# Altium schematic sheet enc-abs_I2C.SchDoc(enc-abs_I2C)
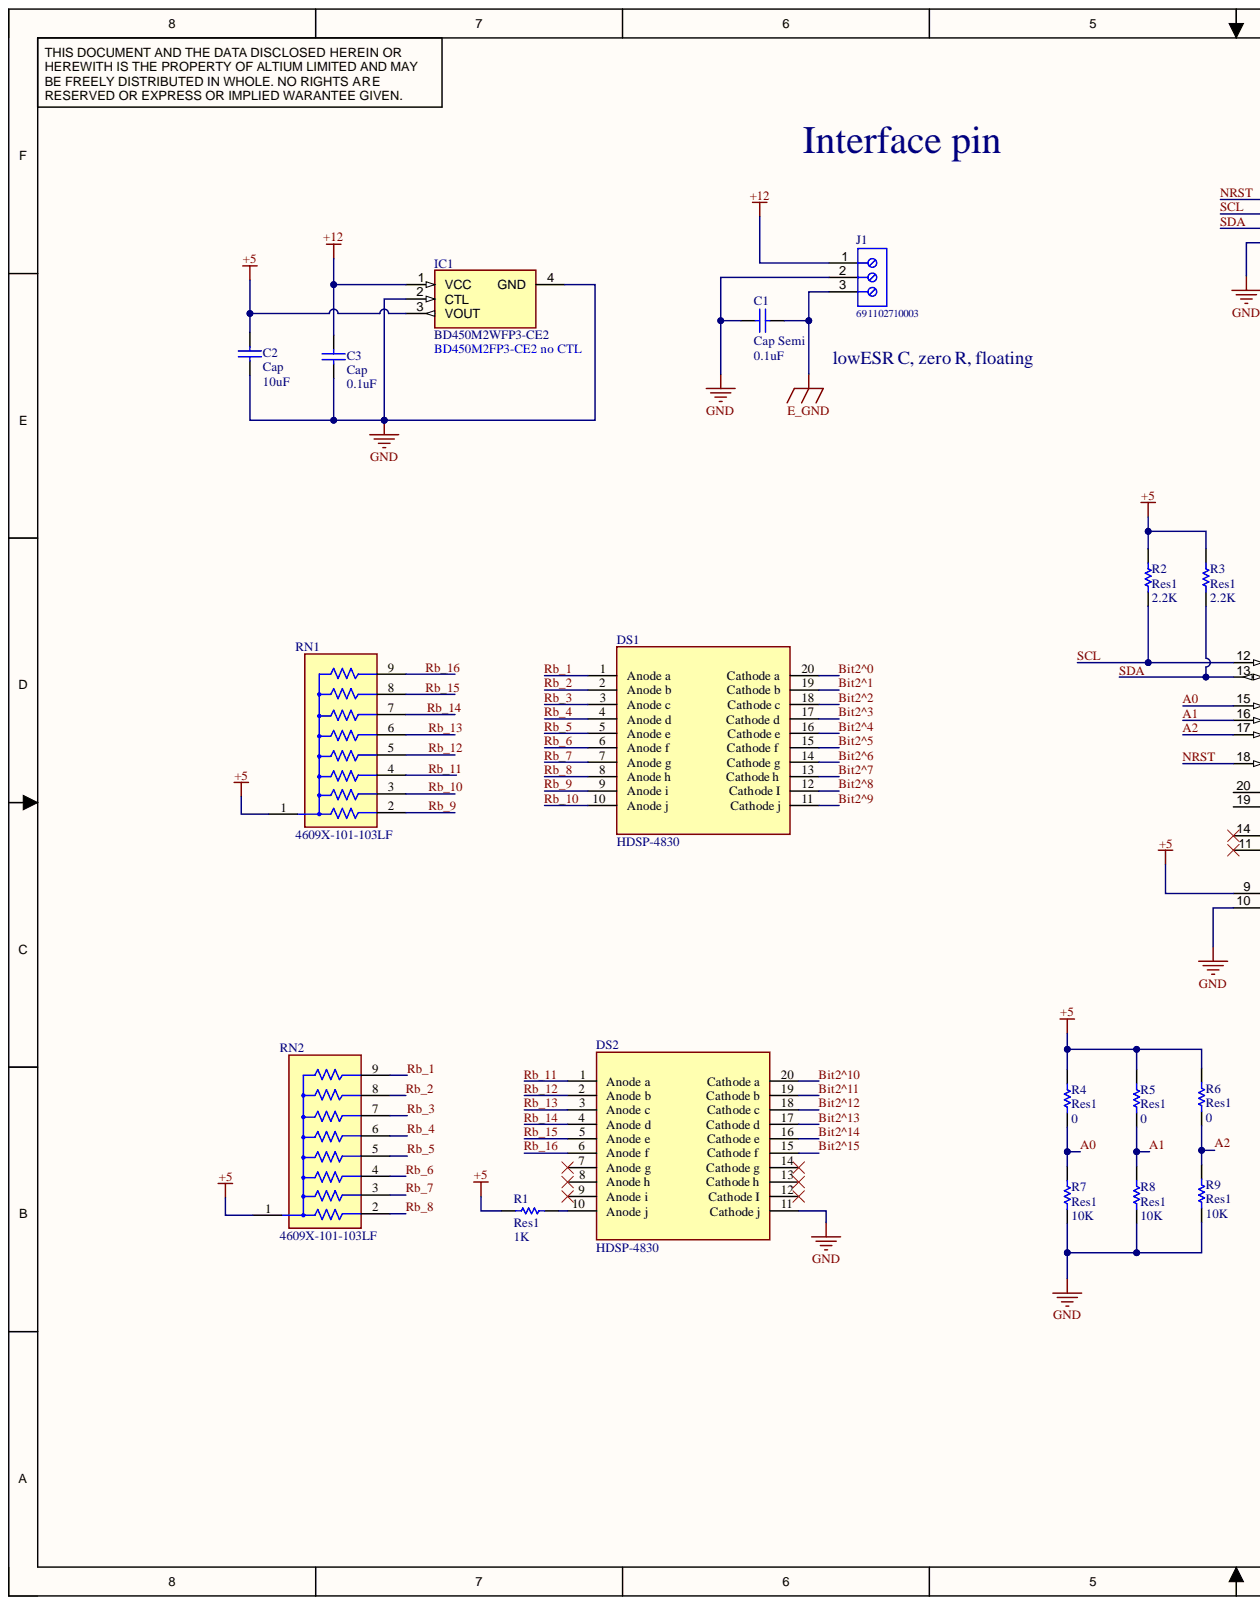
[diagram p0: enc-abs_I2C.SchDoc, left]
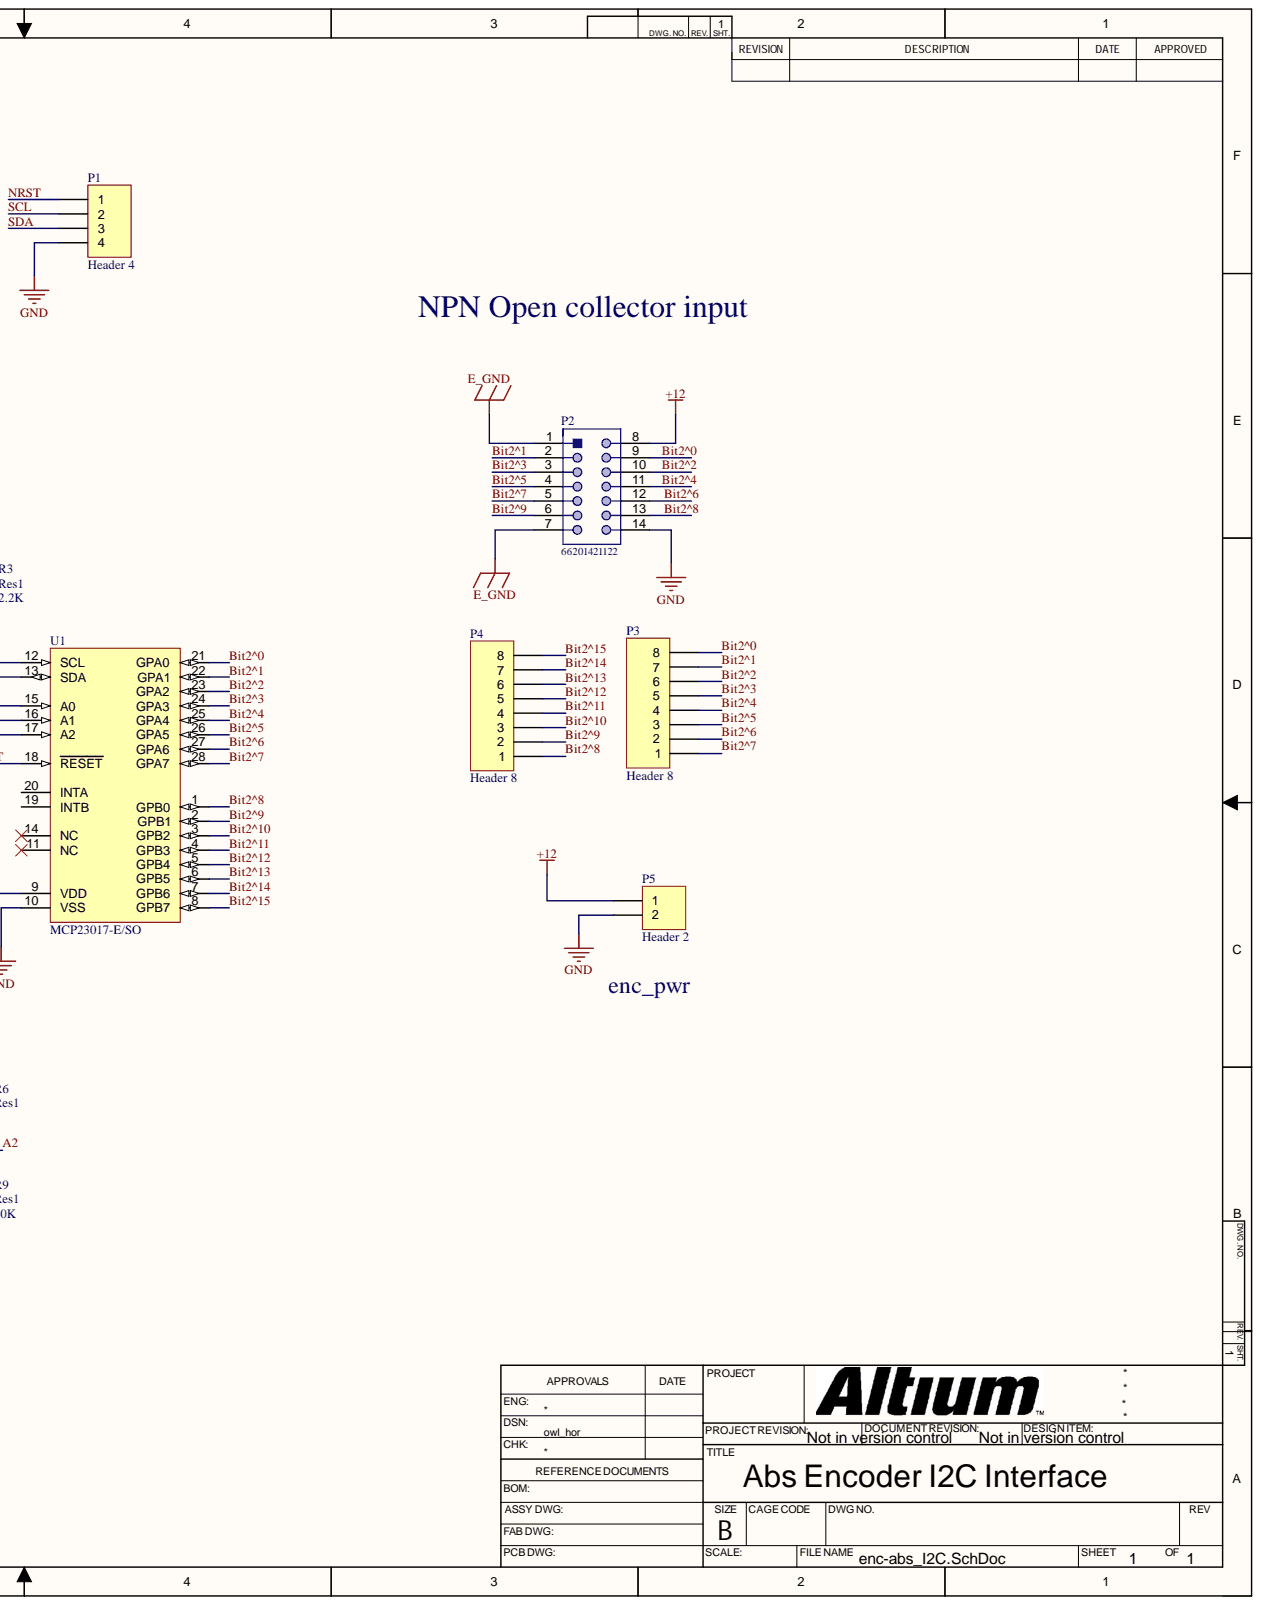
[diagram p1: enc-abs_I2C.SchDoc, right]

## Parts
C1  Cap Semi  value 0.1uF  [C1206]
C2  Cap  value 10uF  [RAD-0.3]
C3  Cap  value 0.1uF  [RAD-0.3]
DS1  HDSP-4830  [Broadcom_HDSP-4830_0]
DS2  HDSP-4830  [Broadcom_HDSP-4830_0]
IC1  BD450M2WFP3-CE2  [SOT230P700X170-4N]
J1  691102710003  [691102710003]
P1  Header 4  [HDR1X4]
P2  66201421122  [66201421122]
P3  Header 8  [HDR1X8]
P4  Header 8  [HDR1X8]
P5  Header 2  [HDR1X2]
R1  Res1  value 1K  [Res_1206_3mm]
R2  Res1  value 2.2K  [AXIAL-0.3]
R3  Res1  value 2.2K  [AXIAL-0.3]
R4  Res1  value 0  [AXIAL-0.3]
R5  Res1  value 0  [AXIAL-0.3]
R6  Res1  value 0  [AXIAL-0.3]
R7  Res1  value 10K  [AXIAL-0.3]
R8  Res1  value 10K  [AXIAL-0.3]
R9  Res1  value 10K  [AXIAL-0.3]
RN1  4609X-101-103LF  [FP-4609X-MFG]
RN2  4609X-101-103LF  [FP-4609X-MFG]
U1  MCP23017-E/SO  [SOIC-SO28_N]

## Nets
+5: C2.2 IC1.3(VOUT) R1.2 R2.2 R3.2 R4.2 R5.2 R6.2 RN1.1 RN2.1 U1.9(VDD)
+12: C3.2 IC1.1(VCC) J1.1 P2.8 P5.1
E_GND: C1.2 J1.3 P2.1 P2.7
GND: C1.1 C2.1 C3.1 DS2.11(Cathode_j) IC1.2(CTL) IC1.4(GND) J1.2 P1.4 P2.14 P5.2 R7.1 R8.1 R9.1 U1.10(VSS)
A0: R4.1 R7.2 U1.15(A0)
A1: R5.1 R8.2 U1.16(A1)
A2: R6.1 R9.2 U1.17(A2)
Bit2^0: DS1.20(Cathode_a) P2.9 P3.8 U1.21(GPA0)
Bit2^1: DS1.19(Cathode_b) P2.2 P3.7 U1.22(GPA1)
Bit2^2: DS1.18(Cathode_c) P2.10 P3.6 U1.23(GPA2)
Bit2^3: DS1.17(Cathode_d) P2.3 P3.5 U1.24(GPA3)
Bit2^4: DS1.16(Cathode_e) P2.11 P3.4 U1.25(GPA4)
Bit2^5: DS1.15(Cathode_f) P2.4 P3.3 U1.26(GPA5)
Bit2^6: DS1.14(Cathode_g) P2.12 P3.2 U1.27(GPA6)
Bit2^7: DS1.13(Cathode_h) P2.5 P3.1 U1.28(GPA7)
Bit2^8: DS1.12(Cathode_I) P2.13 P4.1 U1.1(GPB0)
Bit2^9: DS1.11(Cathode_j) P2.6 P4.2 U1.2(GPB1)
Bit2^10: DS2.20(Cathode_a) P4.3 U1.3(GPB2)
Bit2^11: DS2.19(Cathode_b) P4.4 U1.4(GPB3)
Bit2^12: DS2.18(Cathode_c) P4.5 U1.5(GPB4)
Bit2^13: DS2.17(Cathode_d) P4.6 U1.6(GPB5)
Bit2^14: DS2.16(Cathode_e) P4.7 U1.7(GPB6)
Bit2^15: DS2.15(Cathode_f) P4.8 U1.8(GPB7)
NRST: P1.1 U1.18(RESET)
Rb_1: DS1.1(Anode_a) RN2.9
Rb_2: DS1.2(Anode_b) RN2.8
Rb_3: DS1.3(Anode_c) RN2.7
Rb_4: DS1.4(Anode_d) RN2.6
Rb_5: DS1.5(Anode_e) RN2.5
Rb_6: DS1.6(Anode_f) RN2.4
Rb_7: DS1.7(Anode_g) RN2.3
Rb_8: DS1.8(Anode_h) RN2.2
Rb_9: DS1.9(Anode_i) RN1.2
Rb_10: DS1.10(Anode_j) RN1.3
Rb_11: DS2.1(Anode_a) RN1.4
Rb_12: DS2.2(Anode_b) RN1.5
Rb_13: DS2.3(Anode_c) RN1.6
Rb_14: DS2.4(Anode_d) RN1.7
Rb_15: DS2.5(Anode_e) RN1.8
Rb_16: DS2.6(Anode_f) RN1.9
SCL: P1.2 R2.1 U1.12(SCL)
SDA: P1.3 R3.1 U1.13(SDA)
NetDS2_10: DS2.10(Anode_j) R1.1

Not connected: DS2 7(Anode_g) 8(Anode_h) 9(Anode_i) 12(Cathode_I) 13(Cathode_h) 14(Cathode_g); U1 11(NC) 14(NC) 19(INTB) 20(INTA)

## Library data of the parts
C1 = Cap Semi: Capacitor (Semiconductor SIM Model); Component Kind: Standard; LatestRevisionDate: 29-May-2009; LatestRevisionNote: IPC-7351 Footprint Added.; Library Name: Miscellaneous Devices.IntLib; PackageDescription: Chip Capacitor; PackageReference: C1206; Published: 8-Jun-2000; Signal Integrity: Cap Semi; Simulation: CAP; Publisher: Altium Limited
C2 C3 = Cap: Capacitor; Component Kind: Standard; LatestRevisionDate: 17-Jul-2002; LatestRevisionNote: Re-released for DXP Platform.; Library Name: Miscellaneous Devices.IntLib; PackageDescription: Radial Cap, Thru-Hole; 2 Leads; 0.3 in Pin Spacing; PackageReference: RAD-0.3; Published: 8-Jun-2000; Signal Integrity: Cap; Simulation: CAP; Publisher: Altium Limited
DS1 DS2 = HDSP-4830: 10 Segments LED Array; Component Kind: Standard; Library Name: Schlib1.SchLib
IC1 = BD450M2WFP3-CE2: Integrated Circuit; Manufacturer_Name: ROHM Semiconductor; Component Kind: Standard; Datasheet Link: https://componentsearchengine.com/Datasheets/1/BD450M2WFP3-CE2.pdf; Height: 1.7mm; Manufacturer_Part_Number: BD450M2WFP3-CE2; Mouser Part Number: 755-BD450M2WFP3-CE2; Mouser Price/Stock: https://www.mouser.co.uk/ProductDetail/ROHM-Semiconductor/BD450M2WFP3-CE2?qs=pXoXL5qUNJ0Rp1aMnIGBZQ%3D%3D
J1 = CMP-1502-03259-1: Series 102 - 5.00 mm Horizontal Entry Modular with Pressure Clamp WR-TBL, 3 pin; Manufacturer: Wurth Elektronik; Pin Number: 3; Pitch: 5.00mm; Component Kind: Standard; Datasheet URL: http://katalog.we-online.de/em/datasheet/6911027100xx.pdf; Library Name: Altium Content Vault; Manufacturer URL: http://www.we-online.de; Mounting Technology: THT; Operating Temperature: -40to105degC; Package Reference: 691102710003; Packaging: Box; Part Number: 691102710003; Publisher: WurthElektronik
P1 = Header 4: Header, 4-Pin; Component Kind: Standard; LatestRevisionDate: 17-Jul-2002; LatestRevisionNote: Re-released for DXP Platform.; Library Name: Miscellaneous Connectors.IntLib; Signal Integrity: Connector; Publisher: Altium Limited
P2 = CMP-1502-00314-1: THT Male Header WR-MPC3, Vertical, Dual Row, pitch 3 mm, 14 pins; Manufacturer: Wurth Elektronik; Contact Resistance: 10mOhm; Pin Number: 14; Pitch: 3mm; Working Voltage: 250VAC; Component Kind: Standard; Datasheet URL: https://katalog.we-online.de/em/datasheet/6620xx21122.pdf; Gender: Male; Library Name: Altium Content Vault; Manufacturer URL: http://www.we-online.de; Mounting Technology: THT; Operating Temperature: -40to105degC; Orientation: Vertical; Package Reference: 66201421122; Packaging: Tube; Part Number: 66201421122; Publisher: WurthElektronik
P3 P4 = Header 8: Header, 8-Pin; Component Kind: Standard; LatestRevisionDate: 17-Jul-2002; LatestRevisionNote: Re-released for DXP Platform.; Library Name: Miscellaneous Connectors.IntLib; Signal Integrity: Connector; Publisher: Altium Limited
P5 = Header 2: Header, 2-Pin; Component Kind: Standard; LatestRevisionDate: 17-Jul-2002; LatestRevisionNote: Re-released for DXP Platform.; Library Name: Miscellaneous Connectors.IntLib; Signal Integrity: Connector; Publisher: Altium Limited
R1-R9 = Res1: Resistor; Component Kind: Standard; LatestRevisionDate: 17-Jul-2002; LatestRevisionNote: Re-released for DXP Platform.; Library Name: Miscellaneous Devices.IntLib; PackageDescription: Axial Device, Thru-Hole; 2 Leads; 0.3 in Pin Spacing; PackageReference: AXIAL-0.3; Published: 8-Jun-2000; Signal Integrity: Res; Simulation: RESISTOR; Publisher: Altium Limited
RN1 RN2 = CMP-2000-05605-2: RES ARRAY 8 RES 10K OHM 9SIP; Circuit Type: Bussed; Insulation Resistance: 10MR; Lead Length: 3.43mm; Number of Elements: 8; Number of Terminals/Pins: 9; Power Per Element: 200mW; Power Rating: 1.13W; Resistance: 10kR; Resistance Tolerance: 2%; Temperature Coefficient: 100ppm/°C; Voltage Rating: 100V; Case/Package: SIP; Component Kind: Standard; Contact Plating: Copper; Depth: 2.49mm; Height: 0.2inch; Lead Pitch: 2.49mm; Length: 22.81mm; Library Name: Altium Content Vault; Max Operating Temperature: 125°C; Military Standard: Not; Min Operating Temperature: -55°C; Mount: Through Hole; Number of Pins: 9; Number of Resistors: 8; Package Quantity: 200; Packaging: Bulk; Radiation Hardening: No; REACH SVHC: No SVHC; RoHS Compliant: Yes; Series: 4600X; Technology: THICK FILM; Terminal Pitch: 2.54mm; Termination: Pin; Tolerance: 2%; Width: 0.098inch
U1 = CMP-0186-00161-2: 16-Bit I/O Expander with Serial Interface, 28-Pin SOIC, Extended Temperature; Code_JEDEC: MS-013; Component Kind: Standard; ComponentLink1Description: Manufacturer URL; ComponentLink1URL: http://www.microchip.com/; ComponentLink2Description: Datasheet; ComponentLink2URL: http://ww1.microchip.com/downloads/en/DeviceDoc/21952b.pdf; ComponentLink3Description: Package Specification; ComponentLink3URL: http://www.microchip.com/stellent/groups/techpub_sg/documents/packagingspec/en012702.pdf; DatasheetVersion: revB, Feb-2007; Library Name: Altium Content Vault; PackageDescription: 28-Lead Plastic Small Outline (SO) - Wide 7.50mm Body [SOIC]; PackageReference: SOIC-SO28; PackageVersion: revBB, Aug-2009; RevisionNote: URLs added.
